# Altium schematic sheet 06-CAPTEUR_PRES.SchDoc(06-CAPTEUR_PRES)
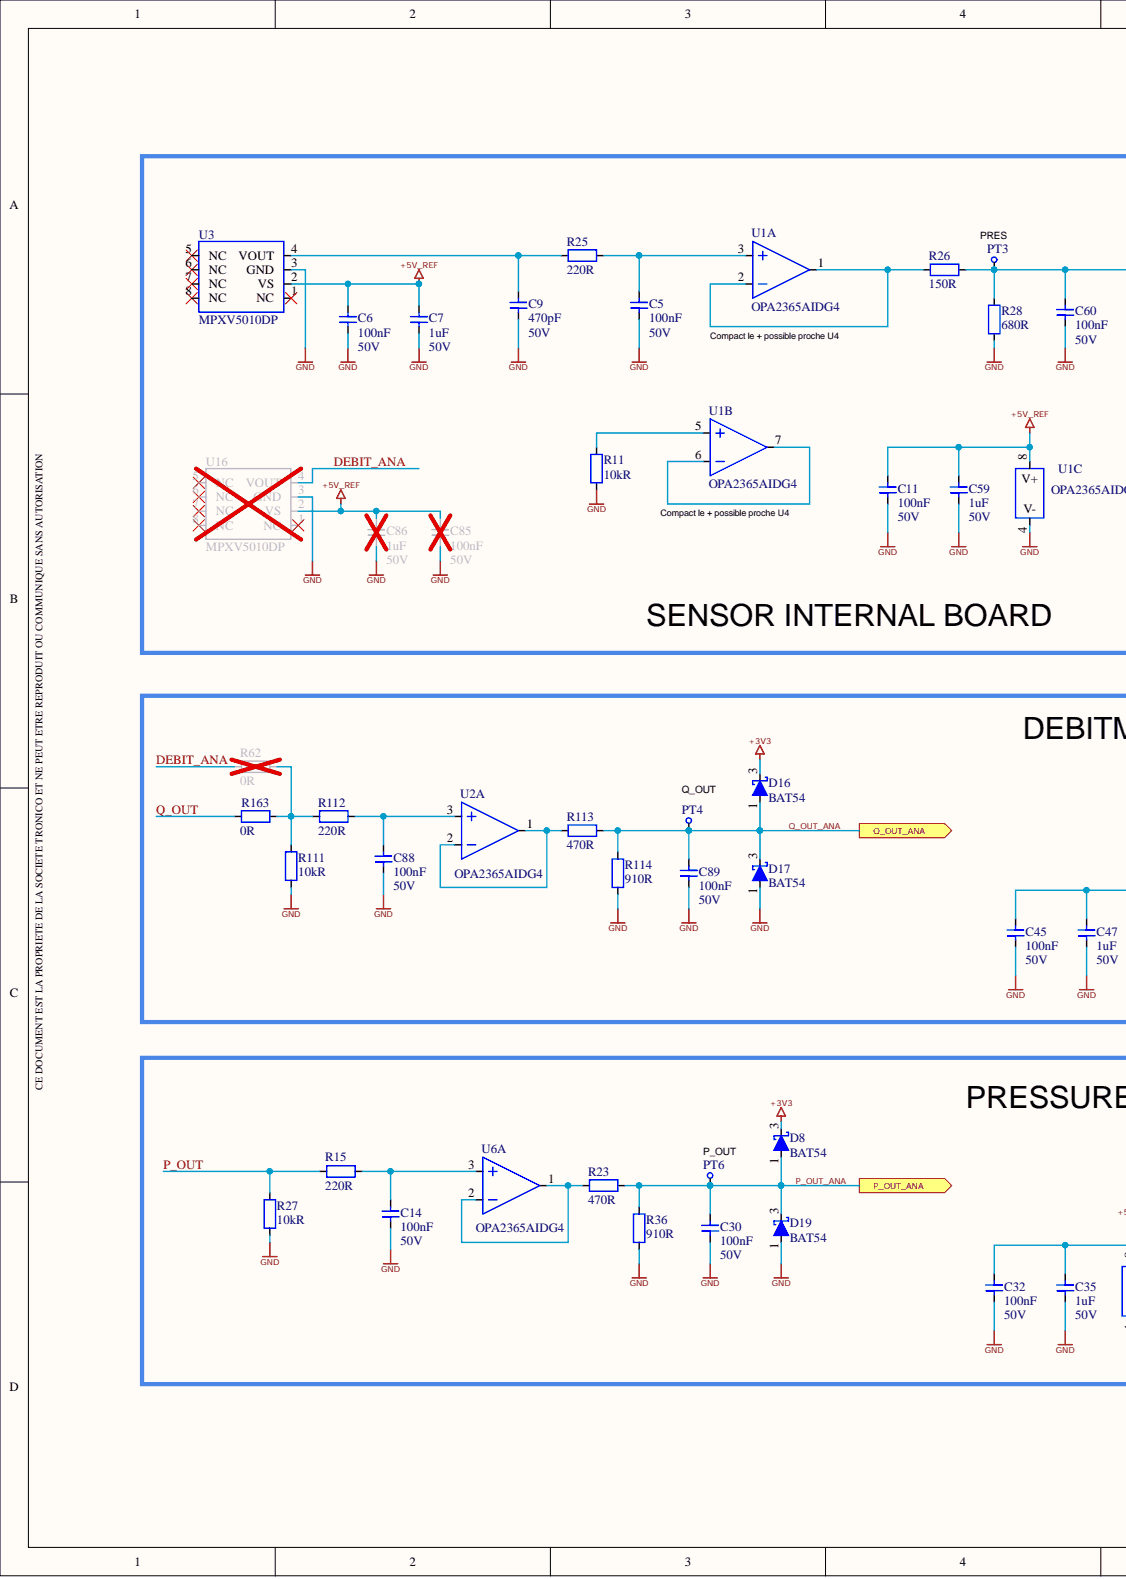
[diagram p0: 06-CAPTEUR_PRES.SchDoc, left]
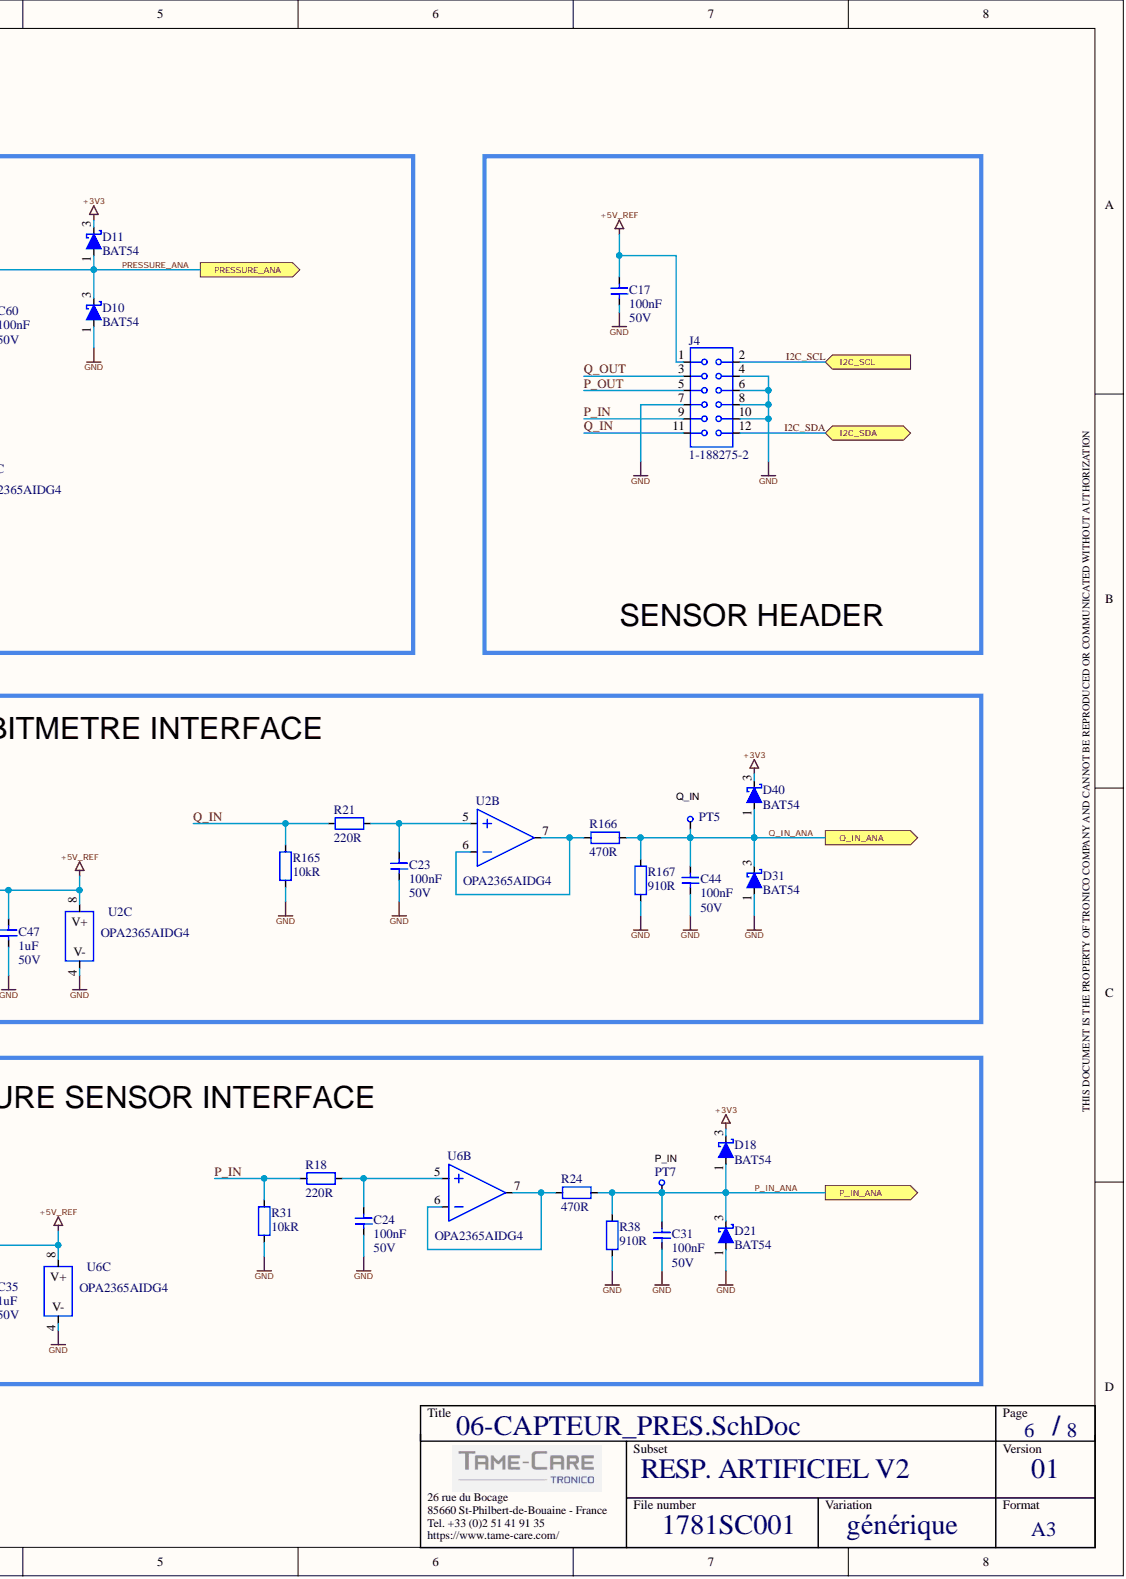
[diagram p1: 06-CAPTEUR_PRES.SchDoc, right]

## Parts
C5  100nF  Parameter 1: 50V  [CAPC_0603X094_T]
C6  100nF  Parameter 1: 50V  [CAPC_0603X094_T]
C7  1uF  Parameter 1: 50V  [CAPC_0603X080_T]
C9  470pF  Parameter 1: 50V  [CAPC_0603X080_T]
C11  100nF  Parameter 1: 50V  [CAPC_0603X094_T]
C14  100nF  Parameter 1: 50V  [CAPC_0603X094_T]
C17  100nF  Parameter 1: 50V  [CAPC_0603X094_T]
C23  100nF  Parameter 1: 50V  [CAPC_0603X094_T]
C24  100nF  Parameter 1: 50V  [CAPC_0603X094_T]
C30  100nF  Parameter 1: 50V  [CAPC_0603X094_T]
C31  100nF  Parameter 1: 50V  [CAPC_0603X094_T]
C32  100nF  Parameter 1: 50V  [CAPC_0603X094_T]
C35  1uF  Parameter 1: 50V  [CAPC_0603X080_T]
C44  100nF  Parameter 1: 50V  [CAPC_0603X094_T]
C45  100nF  Parameter 1: 50V  [CAPC_0603X094_T]
C47  1uF  Parameter 1: 50V  [CAPC_0603X080_T]
C59  1uF  Parameter 1: 50V  [CAPC_0603X080_T]
C60  100nF  Parameter 1: 50V  [CAPC_0603X094_T]
C85  100nF  Parameter 1: 50V  [CAPC_0603X094_T]
C86  1uF  Parameter 1: 50V  [CAPC_0603X080_T]
C88  100nF  Parameter 1: 50V  [CAPC_0603X094_T]
C89  100nF  Parameter 1: 50V  [CAPC_0603X094_T]
D8  BAT54  [SOT23_T]
D10  BAT54  [SOT23_T]
D11  BAT54  [SOT23_T]
D16  BAT54  [SOT23_T]
D17  BAT54  [SOT23_T]
D18  BAT54  [SOT23_T]
D19  BAT54  [SOT23_T]
D21  BAT54  [SOT23_T]
D31  BAT54  [SOT23_T]
D40  BAT54  [SOT23_T]
J4  1-188275-2  [CNT_TE_1-188275-2_B]
PT3  PT_0.4  [PT_0.4-1.0mm]  (value not drawn)  (no BOM)
PT4  PT_0.4  [PT_0.4-1.0mm]  (value not drawn)  (no BOM)
PT5  PT_0.4  [PT_0.4-1.0mm]  (value not drawn)  (no BOM)
PT6  PT_0.4  [PT_0.4-1.0mm]  (value not drawn)  (no BOM)
PT7  PT_0.4  [PT_0.4-1.0mm]  (value not drawn)  (no BOM)
R11  10kR  [RESC_0603X045_T]
R15  220R  [RESC_0603X045_T]
R18  220R  [RESC_0603X045_T]
R21  220R  [RESC_0603X045_T]
R23  470R  [RESC_0603X045_T]
R24  470R  [RESC_0603X045_T]
R25  220R  [RESC_0603X045_T]
R26  150R  [RESC_0603X045_T]
R27  10kR  [RESC_0603X045_T]
R28  680R  [RESC_0603X045_T]
R31  10kR  [RESC_0603X045_T]
R36  910R  [RESC_0603X045_T]
R38  910R  [RESC_0603X045_T]
R62  0R  [RESC_0603X045_T]
R111  10kR  [RESC_0603X045_T]
R112  220R  [RESC_0603X045_T]
R113  470R  [RESC_0603X045_T]
R114  910R  [RESC_0603X045_T]
R163  0R  [RESC_0603X045_T]
R165  10kR  [RESC_0603X045_T]
R166  470R  [RESC_0603X045_T]
R167  910R  [RESC_0603X045_T]
U1  OPA2365AIDG4  [SO8_1.27_5.0X4.0X1.75_B]  (parts A,B,C)
U2  OPA2365AIDG4  [SO8_1.27_5.0X4.0X1.75_B]  (parts A,B,C)
U3  MPXV5010DP  [MPXV5010DP_B]
U6  OPA2365AIDG4  [SO8_1.27_5.0X4.0X1.75_B]  (parts A,B,C)
U16  MPXV5010DP  [MPXV5010DP_B]

## Ports
I2C_SCL, I2C_SDA, P_IN_ANA, P_OUT_ANA, PRESSURE_ANA, Q_IN_ANA, Q_OUT_ANA

## Nets
+3V3: D8.3 D11.3 D16.3 D18.3 D40.3
+5V_REF: C6.2 C7.2 C11.2 C17.2 C32.2 C35.2 C45.2 C47.2 C59.2 C85.2 C86.2 J4.1 U1.8(V+) U2.8(V+) U3.2(VS) U6.8(V+) U16.2(VS)
GND: C5.1 C6.1 C7.1 C9.1 C11.1 C14.1 C17.1 C23.1 C24.1 C30.1 C31.1 C32.1 C35.1 C44.1 C45.1 C47.1 C59.1 C60.1 C85.1 C86.1 C88.1 C89.1 D10.1 D17.1 D19.1 D21.1 D31.1 J4.4 J4.6 J4.7 J4.8 J4.10 R11.2 R27.2 R28.2 R31.2 R36.2 R38.2 R111.2 R114.2 R165.2 R167.2 U1.4(V-) U2.4(V-) U3.3(GND) U6.4(V-) U16.3(GND)
DEBIT_ANA: R62.2 U16.4(VOUT)
I2C_SCL: J4.2 ; port I2C_SCL
I2C_SDA: J4.12 ; port I2C_SDA
P_IN: J4.9 R18.2 R31.1
P_IN_ANA: C31.2 D18.1 D21.3 PT7.1 R24.1 R38.1 ; port P_IN_ANA
P_OUT: J4.5 R15.2 R27.1
P_OUT_ANA: C30.2 D8.1 D19.3 PT6.1 R23.1 R36.1 ; port P_OUT_ANA
PRESSURE_ANA: C60.2 D10.3 D11.1 PT3.1 R26.1 R28.1 ; port PRESSURE_ANA
Q_IN: J4.11 R21.2 R165.1
Q_IN_ANA: C44.2 D31.3 D40.1 PT5.1 R166.1 R167.1 ; port Q_IN_ANA
Q_OUT: J4.3 R163.2
Q_OUT_ANA: C89.2 D16.1 D17.3 PT4.1 R113.1 R114.1 ; port Q_OUT_ANA
NetC5_2: C5.2 R25.1 U1.3
NetC9_2: C9.2 R25.2 U3.4(VOUT)
NetC14_2: C14.2 R15.1 U6.3
NetC23_2: C23.2 R21.1 U2.5
NetC24_2: C24.2 R18.1 U6.5
NetC88_2: C88.2 R112.1 U2.3
NetR11_1: R11.1 U1.5
NetR23_2: R23.2 U6.1 U6.2
NetR24_2: R24.2 U6.6 U6.7
NetR26_2: R26.2 U1.1 U1.2
NetR62_1: R62.1 R111.1 R112.2 R163.1
NetR113_2: R113.2 U2.1 U2.2
NetR166_2: R166.2 U2.6 U2.7
NetU1_6: U1.6 U1.7

Not connected: U3 1(NC) 5(NC) 6(NC) 7(NC) 8(NC); U16 1(NC) 5(NC) 6(NC) 7(NC) 8(NC)

## Library data of the parts
C5 C6 C11 C14 C17 C23 C24 C30-C32 C44 C45 C60 C85 C88 C89 = 23U00036: COND_CERAM CMS 0603 X7R 100nF 50V 10%; REV_NOTE: Maj Champs Techniques; REV_AUTHOR: nlelievre; REF_FAB: 06035C104KAT2A; LEVEL: 1; FAMILLE: CAPACITIFS; FAB: AVX; Database Table Name: v_bt_all; CHAMP9: -55°C/+125°C; CHAMP2: 0603; SS_FAMILLE: COND_CERAM; Simulation: CAP; Signal Integrity: Cap; REV_DATE: 16/10/2019 13:20:57; Library Name: SVNDatabase_BaseTechnique.SVNDbLib; ID_RnD: 23U00036; HelpURL: http://Bt_rnd/ds/02_capacitif/AVX/AVX_cx7r.pdf; ComponentLink2Description: Manufacturer Website; ComponentLink1Description: Manufacturer Datasheet; Component Kind: Standard; CHAMP7: 10%; CHAMP6: 50V; CHAMP5: 100nF; CHAMP3: X7R; CHAMP1: CMS; ACTIF: ACTIF
C7 C35 C47 C59 C86 = 23U00347: COND_CERAM CMS 0603 X5R 1uF 50V 10%; REV_NOTE: Maj Champs Techniques; REV_AUTHOR: nlelievre; REF_FAB: CGA3E3X5R1H105K080AB; LEVEL: 1; FAMILLE: CAPACITIFS; FAB: TDK; Database Table Name: v_bt_all; CHAMP9: -55°C/+85°C; CHAMP2: 0603; SS_FAMILLE: COND_CERAM; Simulation: CAP; Signal Integrity: Cap; REV_DATE: 16/10/2019 13:35:17; Library Name: SVNDatabase_BaseTechnique.SVNDbLib; ID_RnD: 23U00347; HelpURL: http://bt_rnd/ds/02_capacitif/TDK/mlccspec_automotive_general_midvoltage_en.pdf; ComponentLink2Description: Manufacturer Website; ComponentLink1Description: Manufacturer Datasheet; Component Kind: Standard; CHAMP7: 10%; CHAMP6: 50V; CHAMP5: 1uF; CHAMP3: X5R; CHAMP1: CMS; ACTIF: ACTIF
C9 = 23U00388: COND_CERAM CMS 0603 C0G 470pF 50V 5%; REV_NOTE: Migration vers t_bt; REV_AUTHOR: lclavier; REF_FAB: C0603C471J5GACTU; LEVEL: 1; FAMILLE: CAPACITIFS; FAB: KEMET; Database Table Name: v_bt_all; CHAMP9: -55°C/+125°C; CHAMP2: 0603; SS_FAMILLE: COND_CERAM; Simulation: CAP; Signal Integrity: Cap; REV_DATE: 03/09/2019 15:13:32; ID_RnD: 23U00388; HelpURL: http://bt_rnd/ds/02_capacitif/KEMET/C0603C471J5GACTU.pdf; ComponentLink2Description: Manufacturer Website; ComponentLink1Description: Manufacturer Datasheet; Component Kind: Standard; CHAMP7: 5%; CHAMP6: 50V; CHAMP5: 470pF; CHAMP3: C0G; CHAMP1: CMS; ACTIF: ACTIF; Library Name: SVNDatabase_BaseTechnique.SVNDbLib
D8 D10 D11 D16-D19 D21 D31 D40 = 33U00013: DIODE_DE_SIGNAL CMS SOT23  30V 200mA -55°C/+150°C -55°C/+150°C; REV_NOTE: MàJ footprint_path et footprint_ref; REV_AUTHOR: llannuzel; QUALIF: None; LEVEL: 1; FAMILLE: DIODES; FAB: FAIRCHILD; Database Table Name: v_bt_all; SS_FAMILLE: DIODE_DE_SIGNAL; Simulation: SKYDIODE; Signal Integrity: D Schottky; REV_DATE: 13/12/2019 10:03:18; REF_FAB: BAT54; ID_RnD: 33U00013; HelpURL: http://bt_rnd/ds/03_diode/FAIRCHILD/BAT54_x.pdf; ComponentLink2Description: Manufacturer Website; ComponentLink1Description: Manufacturer Datasheet; Component Kind: Standard; CHAMP10: -55°C/+150°C; CHAMP9: -55°C/+150°C; CHAMP6: 200mA; CHAMP5: 30V; CHAMP2: SOT23; CHAMP1: CMS; ACTIF: ACTIF; Library Name: SVNDatabase_BaseTechnique.SVNDbLib
J4 = A7U00321: CONNECT Micro Match CMS 12PTS EMBASE RECT FEM DROIT; REV_NOTE: MaJ Champs Librairies; REV_AUTHOR: lclavier; LEVEL: 1; FAMILLE: CONNECTIQUE; FAB: TE CONNECTIVITY; Database Table Name: v_bt_all; SS_FAMILLE: CONNECT; REV_DATE: 29/04/2020 19:18:04; REF_FAB: 1-188275-2; ID_RnD: A7U00321; HelpURL: http://bt_rnd/ds/10_connectique/TE/ENG_CD_188275_S4.pdf; ComponentLink2Description: Manufacturer Website; ComponentLink1Description: Manufacturer Datasheet; Component Kind: Standard; CHAMP8: DROIT; CHAMP7: FEM; CHAMP6: RECT; CHAMP5: EMBASE; CHAMP4: 12PTS; CHAMP3: CMS; CHAMP1: Micro Match; ACTIF: ACTIF; Library Name: SVNDatabase_BaseTechnique.SVNDbLib
PT3-PT7 = H6U00006: SS_FAMILLE: POINT_TEST; REV_NOTE: Migration vers t_bt; REV_AUTHOR: llannuzel; LEVEL: 1; FAMILLE: DIVERS; FAB: TRONICO; Database Table Name: v_bt_all; CHAMP1: PASTILLE; REV_DATE: 01/05/2020 18:33:20; REF_FAB: PT_0.4; ID_RnD: H6U00006; HelpURL: http://bt_rnd/ds/; ComponentLink2Description: Manufacturer Website; ComponentLink1Description: Manufacturer Datasheet; ACTIF: ACTIF; Library Name: SVNDatabase_BaseTechnique.SVNDbLib
R11 R27 R31 R111 R165 = 11U00108: REST CMS 0603 100PPM 10kR 1/10W 1% -55°C/+155°C; Valide / Non Valide: OK; REV_NOTE: MaJ description; REV_AUTHOR: jrenvoise; REF_FAB: RC0603FR-0710KL; LEVEL: 1; FAMILLE: RESISTIFS; FAB: YAGEO; Database Table Name: v_bt_all; CHAMP2: 0603; SS_FAMILLE: REST; Simulation: RESISTOR; Signal Integrity: Res; REV_DATE: 12/09/2018 10:54:26; ID_RnD: 11U00108; HelpURL: http://bt_rnd/ds/01_resistif/yageo/RC-L_Series.pdf; ComponentLink2Description: Manufacturer Website; ComponentLink1Description: Manufacturer Datasheet; Component Kind: Standard; CHAMP9: -55°C/+155°C; CHAMP7: 1%; CHAMP6: 1/10W; CHAMP5: 10kR; CHAMP3: 100PPM; CHAMP1: CMS; ACTIF: ACTIF; Library Name: SVNDatabase_BaseTechnique.SVNDbLib
R15 R18 R21 R25 R112 = 11U00106: REST CMS 0603 100PPM  220R 1/10W 1%  -55°C/+155°C; Valide / Non Valide: OK; REV_NOTE: MaJ Champs Techniques; REV_AUTHOR: llannuzel; REF_FAB: RC0603FR-07220RL; LEVEL: 1; FAMILLE: RESISTIFS; FAB: YAGEO; Database Table Name: v_bt_all; CHAMP2: 0603; SS_FAMILLE: REST; Simulation: RESISTOR; Signal Integrity: Res; REV_DATE: 11/02/2019 09:50:59; Library Name: SVNDatabase_BaseTechnique.SVNDbLib; ID_RnD: 11U00106; HelpURL: http://bt_rnd/ds/01_resistif/yageo/RC-L_Series.pdf; ComponentLink2Description: Manufacturer Website; ComponentLink1Description: Manufacturer Datasheet; Component Kind: Standard; CHAMP9: -55°C/+155°C; CHAMP7: 1%; CHAMP6: 1/10W; CHAMP5: 220R; CHAMP3: 100PPM; CHAMP1: CMS; ACTIF: ACTIF
R23 R24 R113 R166 = 11U00156: CMS 0603 100PPM  33R 1/10W 1%  -55°C/+155°C; Valide / Non Valide: OK; SS_FAMILLE: REST; REV_NOTE: Migration vers t_bt; REV_AUTHOR: jennifer; REF_FAB: RC0603FR-07470RL; LEVEL: 1; FAMILLE: RESISTIFS; FAB: YAGEO; Database Table Name: v_bt_all; CHAMP2: 0603; Simulation: RESISTOR; Signal Integrity: Res; REV_DATE: 27/07/2018 10:30:40; ID_RnD: 11U00156; HelpURL: http://bt_rnd/ds/01_resistif/yageo/RC-L_Series.pdf; ComponentLink2Description: Manufacturer Website; ComponentLink1Description: Manufacturer Datasheet; Component Kind: Standard; CHAMP9: -55°C/+155°C; CHAMP7: 1%; CHAMP6: 1/10W; CHAMP5: 470R; CHAMP3: 100PPM; CHAMP1: CMS; ACTIF: ACTIF; Library Name: SVNDatabase_BaseTechnique.SVNDbLib
R26 = 11U00074: REST CMS 0603 100PPM 150R 1/10W 1% -55°C/+155°C; Valide / Non Valide: OK; REV_NOTE: MaJ description; REV_AUTHOR: jrenvoise; REF_FAB: RC0603FR-07150RL; LEVEL: 1; FAMILLE: RESISTIFS; FAB: YAGEO; Database Table Name: v_bt_all; CHAMP2: 0603; SS_FAMILLE: REST; Simulation: RESISTOR; Signal Integrity: Res; REV_DATE: 12/09/2018 10:54:26; ID_RnD: 11U00074; HelpURL: http://bt_rnd/ds/01_resistif/yageo/RC-L_Series.pdf; ComponentLink2Description: Manufacturer Website; ComponentLink1Description: Manufacturer Datasheet; Component Kind: Standard; CHAMP9: -55°C/+155°C; CHAMP7: 1%; CHAMP6: 1/10W; CHAMP5: 150R; CHAMP3: 100PPM; CHAMP1: CMS; ACTIF: ACTIF; Library Name: SVNDatabase_BaseTechnique.SVNDbLib
R28 = 11U00072: REST CMS 0603 100PPM  680R 1/10W 1% -55°C/+155°C; Valide / Non Valide: OK; REV_NOTE: MaJ description; REV_AUTHOR: jrenvoise; REF_FAB: RC0603FR-07680RL; LEVEL: 1; FAMILLE: RESISTIFS; FAB: YAGEO; Database Table Name: v_bt_all; CHAMP2: 0603; SS_FAMILLE: REST; Simulation: RESISTOR; Signal Integrity: Res; REV_DATE: 12/09/2018 11:17:00; ID_RnD: 11U00072; HelpURL: http://bt_rnd/ds/01_resistif/YAGEO/RC-L_Series.pdf; ComponentLink2Description: Manufacturer Website; ComponentLink1Description: Manufacturer Datasheet; Component Kind: Standard; CHAMP9: -55°C/+155°C; CHAMP7: 1%; CHAMP6: 1/10W; CHAMP5: 680R; CHAMP3: 100PPM; CHAMP1: CMS; ACTIF: ACTIF; Library Name: SVNDatabase_BaseTechnique.SVNDbLib
R36 R38 R114 R167 = 11U00282: CMS 0603 100PPM  910R 1/10W 1%  -55°C/+155°C; Valide / Non Valide: OK; SS_FAMILLE: REST; REV_NOTE: Migration vers t_bt; REV_AUTHOR: jennifer; REF_FAB: RC0603FR-07910RL; LEVEL: 1; FAMILLE: RESISTIFS; FAB: YAGEO; Database Table Name: v_bt_all; CHAMP2: 0603; Simulation: RESISTOR; Signal Integrity: Res; REV_DATE: 27/07/2018 10:30:47; ID_RnD: 11U00282; HelpURL: http://bt_rnd/ds/01_resistif/yageo/RC-L_Series.pdf; ComponentLink2Description: Manufacturer Website; ComponentLink1Description: Manufacturer Datasheet; Component Kind: Standard; CHAMP9: -55°C/+155°C; CHAMP7: 1%; CHAMP6: 1/10W; CHAMP5: 910R; CHAMP3: 100PPM; CHAMP1: CMS; ACTIF: ACTIF; Library Name: SVNDatabase_BaseTechnique.SVNDbLib
R62 R163 = 11U00009: REST CMS 0603 100PPM  0R 1/10W 20mR -55°C/+155°C; Valide / Non Valide: OK; REV_NOTE: MaJ Champs URL; REV_AUTHOR: jrenvoise; REF_FAB: CRCW06030000Z0EA; LEVEL: 1; FAMILLE: RESISTIFS; FAB: VISHAY; Database Table Name: v_bt_all; CHAMP2: 0603; SS_FAMILLE: REST; Simulation: RESISTOR; Signal Integrity: Res; REV_DATE: 24/10/2018 10:43:14; ID_RnD: 11U00009; HelpURL: http://bt_rnd/ds/01_resistif/vishay/Vishay_D-CRCWE3.pdf; ComponentLink2Description: Manufacturer Website; ComponentLink1URL: http://www.vishay.com/docs/20035/dcrcwe3.pdf; ComponentLink1Description: Manufacturer Datasheet; Component Kind: Standard; CHAMP9: -55°C/+155°C; CHAMP8: 20mR; CHAMP6: 1/10W; CHAMP5: 0R; CHAMP3: 100PPM; CHAMP1: CMS; ACTIF: ACTIF; Library Name: SVNDatabase_BaseTechnique.SVNDbLib
U1 U2 U6 = 61U00068: CI_AOP_COMPAR CMS SO8 DUAL AOP +2.2V/+5.5V L NOISE; REV_NOTE: Maj Footprint Ref; REV_AUTHOR: jcaille; LEVEL: 1; FAMILLE: CIRCUITS_INTEGRES; FAB: TEXAS INSTRUMENTS; Database Table Name: v_bt_all; CHAMP10: -65°C/+150°C; CHAMP9: -40°C/+150°C; CHAMP8: L NOISE; SS_FAMILLE: CI_AOP_COMPAR; REV_DATE: 12/02/2020 16:04:35; REF_FAB: OPA2365AIDG4; ID_RnD: 61U00068; HelpURL: http://bt_rnd/ds/06_ci/TI/opa2365.pdf; ComponentLink2Description: Manufacturer Website; ComponentLink1Description: Manufacturer Datasheet; Component Kind: Standard; CHAMP7: +2.2V/+5.5V; CHAMP6: AOP; CHAMP5: DUAL; CHAMP2: SO8; CHAMP1: CMS; ACTIF: ACTIF; Library Name: SVNDatabase_BaseTechnique.SVNDbLib
U3 U16 = 68U00002: CI_CAPTEUR_PRESSION CMS 1351 ANALOG +4.75V/+5.25V 0PSI/1.45PSI 5%; REV_NOTE: MaJ Champs Librairies; REV_AUTHOR: lclavier; LEVEL: 1; FAMILLE: CIRCUITS_INTEGRES; FAB: NXP; Database Table Name: v_bt_all; CHAMP10: -40°C/+125°C; CHAMP2: 1351; SS_FAMILLE: CI_CAPTEUR_PRESSION; REV_DATE: 20/04/2020 18:20:06; REF_FAB: MPXV5010DP; ID_RnD: 68U00002; HelpURL: http://bt_rnd/ds/06_ci/NXP/MPX5010.pdf; ComponentLink2Description: Manufacturer Website; ComponentLink1Description: Manufacturer Datasheet; Component Kind: Standard; CHAMP9: -40°C/+125°C; CHAMP8: 5%; CHAMP7: 0PSI/1.45PSI; CHAMP6: +4.75V/+5.25V; CHAMP5: ANALOG; CHAMP1: CMS; ACTIF: ACTIF; Library Name: SVNDatabase_BaseTechnique.SVNDbLib
